# Altium schematic sheet Zotino.schdoc
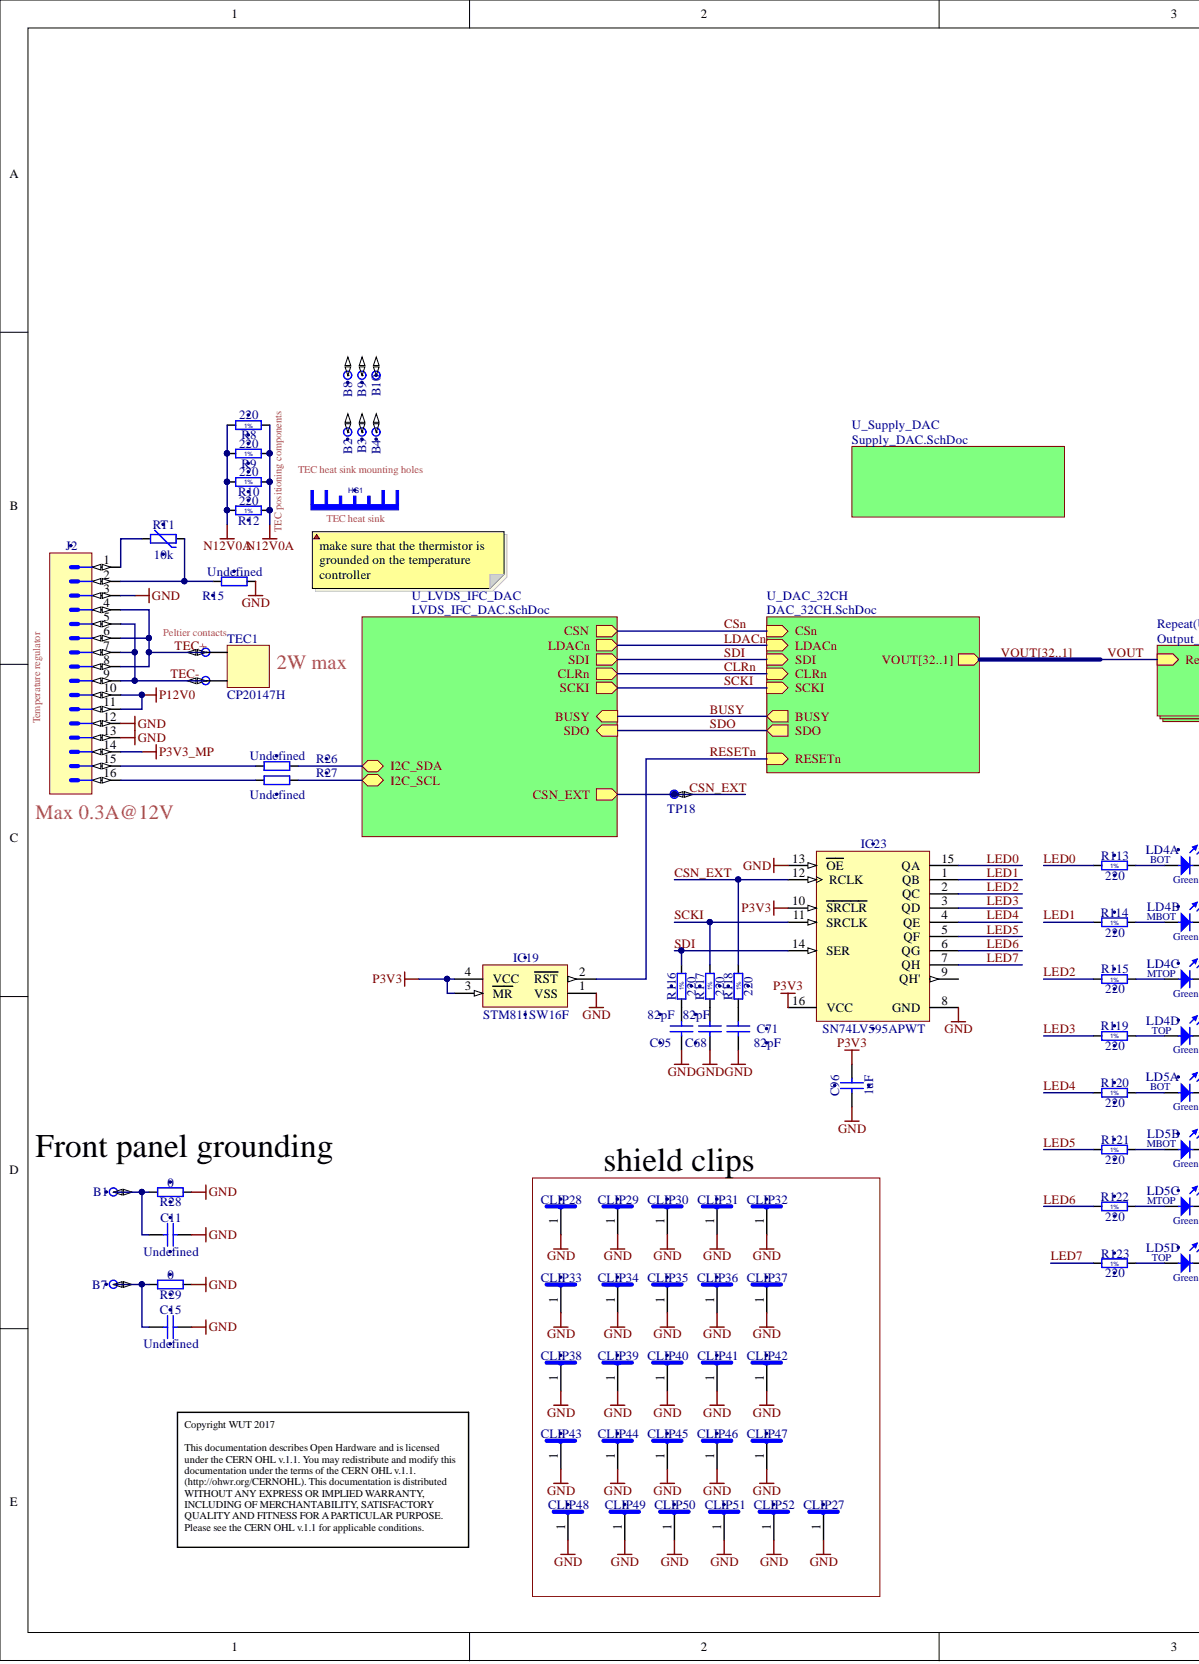
[diagram p0: Zotino.schdoc, left]
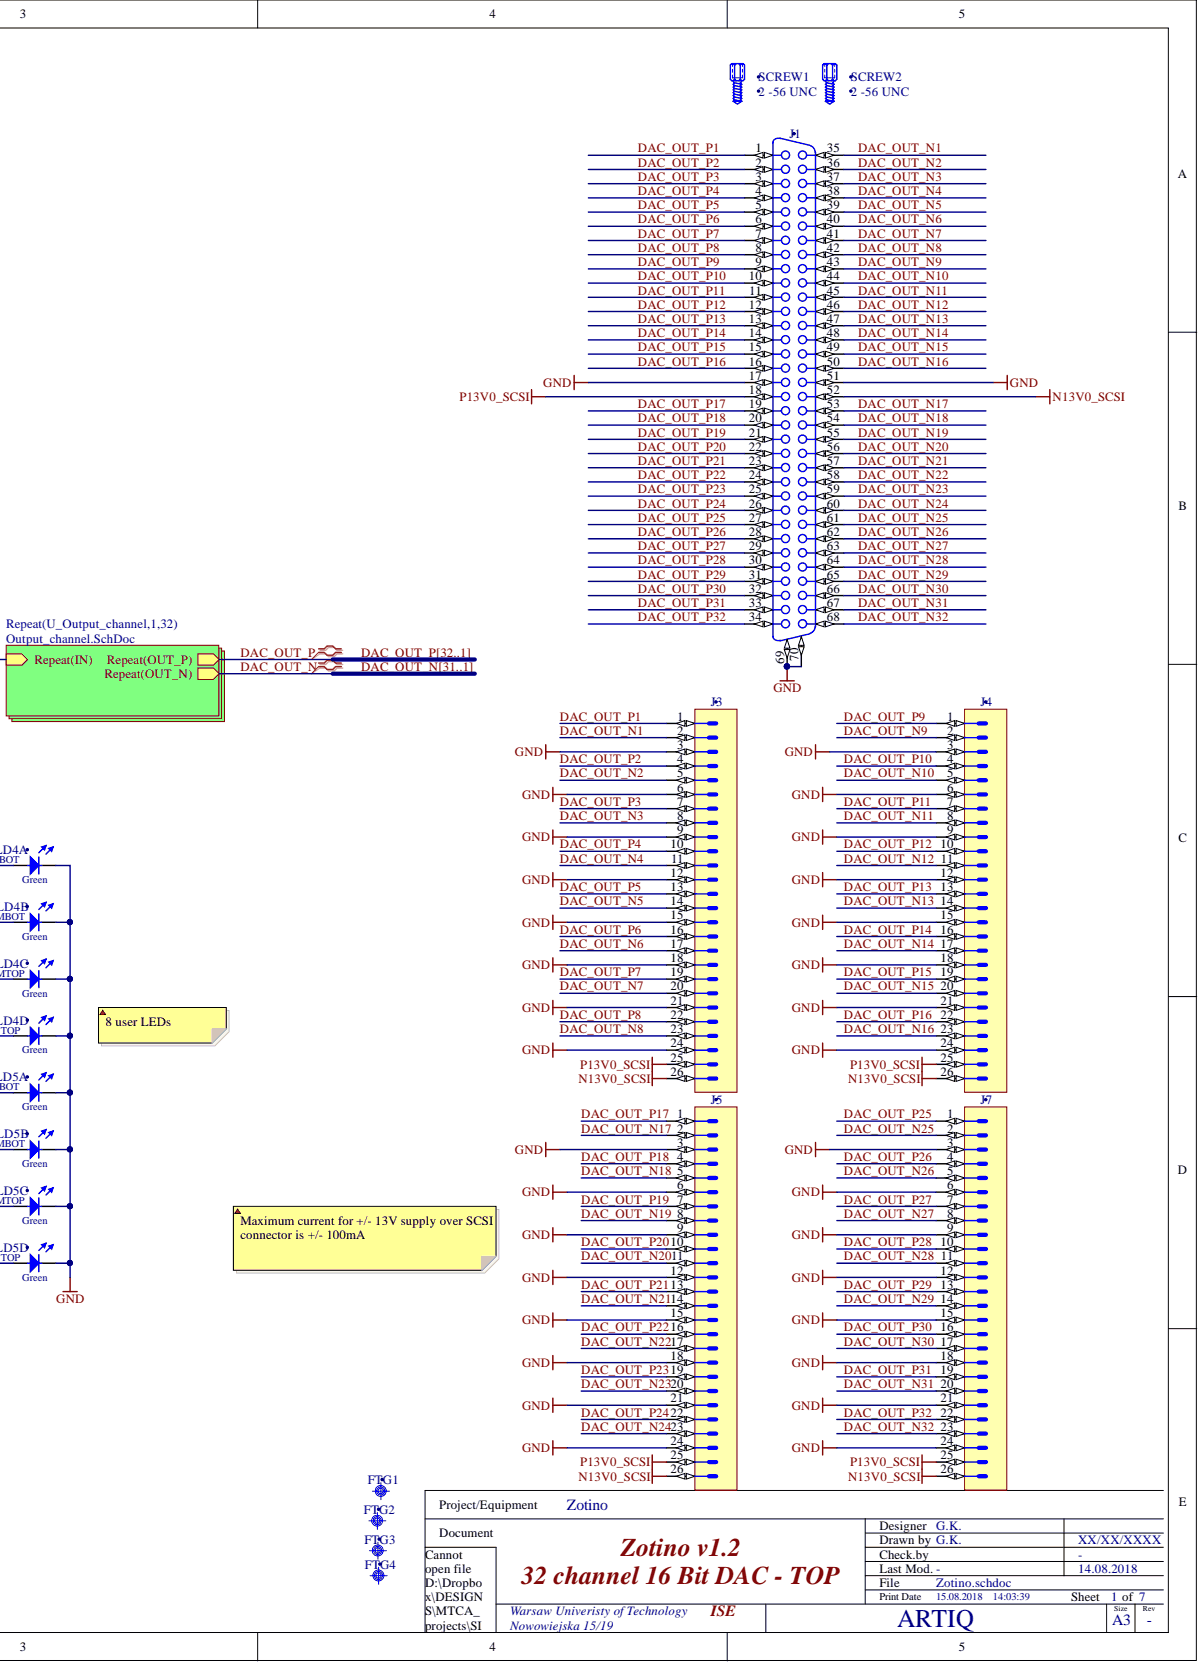
[diagram p1: Zotino.schdoc, right]

## Parts
B1  Plated Hole  [MTG270_500]  (value not drawn)  (no BOM)
B2  Plated Hole  [c130h80]  (value not drawn)  (no BOM)
B3  Plated Hole  [c130h80]  (value not drawn)  (no BOM)
B4  Plated Hole  [c130h80]  (value not drawn)  (no BOM)
B5  Plated Hole  [c160h110]  (value not drawn)  (no BOM)
B6  Plated Hole  [c160h110]  (value not drawn)  (no BOM)
B7  Plated Hole  [MTG270_500]  (value not drawn)  (no BOM)
B8  Plated Hole  [c130h80]  (value not drawn)  (no BOM)
B9  Plated Hole  [c130h80]  (value not drawn)  (no BOM)
B10  Plated Hole  [c130h80]  (value not drawn)  (no BOM)
C11  Undefined  [CAPC2012X135N]
C15  Undefined  [CAPC2012X135N]
C68  82pF  [CAPC1608X87N]
C71  82pF  [CAPC1608X87N]
C95  82pF  [CAPC1608X87N]
C96  1uF  [CAPC1608X87N]
CLIP27  S1411-46R  [CLIP_HOLLAND-SHIELDING_LC]  (value not drawn)
CLIP28  S1411-46R  [CLIP_HOLLAND-SHIELDING_LC]  (value not drawn)
CLIP29  S1411-46R  [CLIP_HOLLAND-SHIELDING_LC]  (value not drawn)
CLIP30  S1411-46R  [CLIP_HOLLAND-SHIELDING_LC]  (value not drawn)
CLIP31  S1411-46R  [CLIP_HOLLAND-SHIELDING_LC]  (value not drawn)
CLIP32  S1411-46R  [CLIP_HOLLAND-SHIELDING_LC]  (value not drawn)
CLIP33  S1411-46R  [CLIP_HOLLAND-SHIELDING_LC]  (value not drawn)
CLIP34  S1411-46R  [CLIP_HOLLAND-SHIELDING_LC]  (value not drawn)
CLIP35  S1411-46R  [CLIP_HOLLAND-SHIELDING_LC]  (value not drawn)
CLIP36  S1411-46R  [CLIP_HOLLAND-SHIELDING_LC]  (value not drawn)
CLIP37  S1411-46R  [CLIP_HOLLAND-SHIELDING_LC]  (value not drawn)
CLIP38  S1411-46R  [CLIP_HOLLAND-SHIELDING_LC]  (value not drawn)
CLIP39  S1411-46R  [CLIP_HOLLAND-SHIELDING_LC]  (value not drawn)
CLIP40  S1411-46R  [CLIP_HOLLAND-SHIELDING_LC]  (value not drawn)
CLIP41  S1411-46R  [CLIP_HOLLAND-SHIELDING_LC]  (value not drawn)
CLIP42  S1411-46R  [CLIP_HOLLAND-SHIELDING_LC]  (value not drawn)
CLIP43  S1411-46R  [CLIP_HOLLAND-SHIELDING_LC]  (value not drawn)
CLIP44  S1411-46R  [CLIP_HOLLAND-SHIELDING_LC]  (value not drawn)
CLIP45  S1411-46R  [CLIP_HOLLAND-SHIELDING_LC]  (value not drawn)
CLIP46  S1411-46R  [CLIP_HOLLAND-SHIELDING_LC]  (value not drawn)
CLIP47  S1411-46R  [CLIP_HOLLAND-SHIELDING_LC]  (value not drawn)
CLIP48  S1411-46R  [CLIP_HOLLAND-SHIELDING_LC]  (value not drawn)
CLIP49  S1411-46R  [CLIP_HOLLAND-SHIELDING_LC]  (value not drawn)
CLIP50  S1411-46R  [CLIP_HOLLAND-SHIELDING_LC]  (value not drawn)
CLIP51  S1411-46R  [CLIP_HOLLAND-SHIELDING_LC]  (value not drawn)
CLIP52  S1411-46R  [CLIP_HOLLAND-SHIELDING_LC]  (value not drawn)
FTG1  Use only in PCB  [FIDUCIAL_TOP_C100-200]  (value not drawn)  (no BOM)
FTG2  Use only in PCB  [FIDUCIAL_TOP_S100-200]  (value not drawn)  (no BOM)
FTG3  Use only in PCB  [FIDUCIAL_TOP_S200-400]  (value not drawn)  (no BOM)
FTG4  Use only in PCB  [FIDUCIAL_TOP_S100-200]  (value not drawn)  (no BOM)
HS1  Heat Sink No Pad  [HSINK_ATS_ATS-56001-C3-R0]  (value not drawn)
IC19  STM811SW16F  [SOT143N]
IC23  SN74LV595APWT  [SOP65P640X120-16N]
J1  D-SUB  [TYCO_5787082-7]  (value not drawn)
J2  Header 16  [3M_N2516-6002RB]  (value not drawn)
J3  Header 26  [3M_N2526-6002RB]  (value not drawn)
J4  Header 26  [3M_N2526-6002RB]  (value not drawn)
J5  Header 26  [3M_N2526-6002RB]  (value not drawn)
J7  Header 26  [3M_N2526-6002RB]  (value not drawn)
LD4  Green  [LED_BIVAR_H485CGDL]  (parts A,B,C,D)
LD5  Green  [LED_BIVAR_H485CGDL]  (parts A,B,C,D)
R8  220  [RESC1608X55N]
R9  220  [RESC1608X55N]
R10  220  [RESC1608X55N]
R12  220  [RESC1608X55N]
R15  Undefined  [RESC1608X55N]
R26  Undefined  [RESC1608X55N]
R27  Undefined  [RESC1608X55N]
R28  0  [RESC1608X55N]
R29  0  [RESC1608X55N]
R113  220  [RESC1608X55N]
R114  220  [RESC1608X55N]
R115  220  [RESC1608X55N]
R116  220  [RESC1608X55N]
R117  220  [RESC1608X55N]
R118  220  [RESC1608X55N]
R119  220  [RESC1608X55N]
R120  220  [RESC1608X55N]
R121  220  [RESC1608X55N]
R122  220  [RESC1608X55N]
R123  220  [RESC1608X55N]
RT1  10k  [THERMC1608X90N]
SCREW1  2 -56 UNC  [TYCO_786585-2]
SCREW2  2 -56 UNC  [TYCO_786585-2]
TEC1  CP20147H  [TEC_CP20147H]
TP18  Test Point  [TPS127]  (value not drawn)  (no BOM)

## Nets
GND: C11.2 C15.2 C68.1 C71.1 C95.1 C96.1 CLIP27.1 CLIP28.1 CLIP29.1 CLIP30.1 CLIP31.1 CLIP32.1 CLIP33.1 CLIP34.1 CLIP35.1 CLIP36.1 CLIP37.1 CLIP38.1 CLIP39.1 CLIP40.1 CLIP41.1 CLIP42.1 CLIP43.1 CLIP44.1 CLIP45.1 CLIP46.1 CLIP47.1 CLIP48.1 CLIP49.1 CLIP50.1 CLIP51.1 CLIP52.1 IC19.1(VSS) IC23.8(GND) IC23.13(OE) J1.17 J1.51 J1.69 J1.70 J2.3 J2.12 J2.13 J3.3 J3.6 J3.9 J3.12 J3.15 J3.18 J3.21 J3.24 J4.3 J4.6 J4.9 J4.12 J4.15 J4.18 J4.21 J4.24 J5.3 J5.6 J5.9 J5.12 J5.15 J5.18 J5.21 J5.24 J7.3 J7.6 J7.9 J7.12 J7.15 J7.18 J7.21 J7.24 LD4.1 LD4.3 LD4.5 LD4.7 LD5.1 LD5.3 LD5.5 LD5.7 R15.1 R28.1 R29.1
N12V0A: R8.1 R8.2 R9.1 R9.2 R10.1 R10.2 R12.1 R12.2
N13V0_SCSI: J1.52 J3.26 J4.26 J5.26 J7.26
P3V3: C96.2 IC19.3(MR) IC19.4(VCC) IC23.10(SRCLR) IC23.16(VCC)
P3V3_MP: J2.14
P12V0: J2.10 J2.11
P13V0_SCSI: J1.18 J3.25 J4.25 J5.25 J7.25
CSN_EXT: IC23.12(RCLK) R118.2 TP18.1
DAC_OUT_N1: J1.35 J3.2  [also labelled DAC_OUT_N]
DAC_OUT_N2: J1.36 J3.5  [also labelled DAC_OUT_N]
DAC_OUT_N3: J1.37 J3.8  [also labelled DAC_OUT_N]
DAC_OUT_N4: J1.38 J3.11  [also labelled DAC_OUT_N]
DAC_OUT_N5: J1.39 J3.14  [also labelled DAC_OUT_N]
DAC_OUT_N6: J1.40 J3.17  [also labelled DAC_OUT_N]
DAC_OUT_N7: J1.41 J3.20  [also labelled DAC_OUT_N]
DAC_OUT_N8: J1.42 J3.23  [also labelled DAC_OUT_N]
DAC_OUT_N9: J1.43 J4.2  [also labelled DAC_OUT_N]
DAC_OUT_N10: J1.44 J4.5  [also labelled DAC_OUT_N]
DAC_OUT_N11: J1.45 J4.8  [also labelled DAC_OUT_N]
DAC_OUT_N12: J1.46 J4.11  [also labelled DAC_OUT_N]
DAC_OUT_N13: J1.47 J4.14  [also labelled DAC_OUT_N]
DAC_OUT_N14: J1.48 J4.17  [also labelled DAC_OUT_N]
DAC_OUT_N15: J1.49 J4.20  [also labelled DAC_OUT_N]
DAC_OUT_N16: J1.50 J4.23  [also labelled DAC_OUT_N]
DAC_OUT_N17: J1.53 J5.2  [also labelled DAC_OUT_N]
DAC_OUT_N18: J1.54 J5.5  [also labelled DAC_OUT_N]
DAC_OUT_N19: J1.55 J5.8  [also labelled DAC_OUT_N]
DAC_OUT_N20: J1.56 J5.11  [also labelled DAC_OUT_N]
DAC_OUT_N21: J1.57 J5.14  [also labelled DAC_OUT_N]
DAC_OUT_N22: J1.58 J5.17  [also labelled DAC_OUT_N]
DAC_OUT_N23: J1.59 J5.20  [also labelled DAC_OUT_N]
DAC_OUT_N24: J1.60 J5.23  [also labelled DAC_OUT_N]
DAC_OUT_N25: J1.61 J7.2  [also labelled DAC_OUT_N]
DAC_OUT_N26: J1.62 J7.5  [also labelled DAC_OUT_N]
DAC_OUT_N27: J1.63 J7.8  [also labelled DAC_OUT_N]
DAC_OUT_N28: J1.64 J7.11  [also labelled DAC_OUT_N]
DAC_OUT_N29: J1.65 J7.14  [also labelled DAC_OUT_N]
DAC_OUT_N30: J1.66 J7.17  [also labelled DAC_OUT_N]
DAC_OUT_N31: J1.67 J7.20  [also labelled DAC_OUT_N]
DAC_OUT_N32: J1.68 J7.23  [also labelled DAC_OUT_N]
DAC_OUT_P1: J1.1 J3.1  [also labelled DAC_OUT_P]
DAC_OUT_P2: J1.2 J3.4  [also labelled DAC_OUT_P]
DAC_OUT_P3: J1.3 J3.7  [also labelled DAC_OUT_P]
DAC_OUT_P4: J1.4 J3.10  [also labelled DAC_OUT_P]
DAC_OUT_P5: J1.5 J3.13  [also labelled DAC_OUT_P]
DAC_OUT_P6: J1.6 J3.16  [also labelled DAC_OUT_P]
DAC_OUT_P7: J1.7 J3.19  [also labelled DAC_OUT_P]
DAC_OUT_P8: J1.8 J3.22  [also labelled DAC_OUT_P]
DAC_OUT_P9: J1.9 J4.1  [also labelled DAC_OUT_P]
DAC_OUT_P10: J1.10 J4.4  [also labelled DAC_OUT_P]
DAC_OUT_P11: J1.11 J4.7  [also labelled DAC_OUT_P]
DAC_OUT_P12: J1.12 J4.10  [also labelled DAC_OUT_P]
DAC_OUT_P13: J1.13 J4.13  [also labelled DAC_OUT_P]
DAC_OUT_P14: J1.14 J4.16  [also labelled DAC_OUT_P]
DAC_OUT_P15: J1.15 J4.19  [also labelled DAC_OUT_P]
DAC_OUT_P16: J1.16 J4.22  [also labelled DAC_OUT_P]
DAC_OUT_P17: J1.19 J5.1  [also labelled DAC_OUT_P]
DAC_OUT_P18: J1.20 J5.4  [also labelled DAC_OUT_P]
DAC_OUT_P19: J1.21 J5.7  [also labelled DAC_OUT_P]
DAC_OUT_P20: J1.22 J5.10  [also labelled DAC_OUT_P]
DAC_OUT_P21: J1.23 J5.13  [also labelled DAC_OUT_P]
DAC_OUT_P22: J1.24 J5.16  [also labelled DAC_OUT_P]
DAC_OUT_P23: J1.25 J5.19  [also labelled DAC_OUT_P]
DAC_OUT_P24: J1.26 J5.22  [also labelled DAC_OUT_P]
DAC_OUT_P25: J1.27 J7.1  [also labelled DAC_OUT_P]
DAC_OUT_P26: J1.28 J7.4  [also labelled DAC_OUT_P]
DAC_OUT_P27: J1.29 J7.7  [also labelled DAC_OUT_P]
DAC_OUT_P28: J1.30 J7.10  [also labelled DAC_OUT_P]
DAC_OUT_P29: J1.31 J7.13  [also labelled DAC_OUT_P]
DAC_OUT_P30: J1.32 J7.16  [also labelled DAC_OUT_P]
DAC_OUT_P31: J1.33 J7.19  [also labelled DAC_OUT_P]
DAC_OUT_P32: J1.34 J7.22  [also labelled DAC_OUT_P]
LED0: IC23.15(QA) R113.1
LED1: IC23.1(QB) R114.1
LED2: IC23.2(QC) R115.1
LED3: IC23.3(QD) R119.1
LED4: IC23.4(QE) R120.1
LED5: IC23.5(QF) R121.1
LED6: IC23.6(QG) R122.1
LED7: IC23.7(QH) R123.1
RESETn: IC19.2(RST)
SCKI: IC23.11(SRCLK) R117.2
SDI: IC23.14(SER) R116.2
TEC+: B5.1 J2.4 J2.6 J2.8
TEC-: B6.1 J2.5 J2.7 J2.9
NetB1_1: B1.1 C11.1 R28.2
NetB2_1: B2.1
NetB3_1: B3.1
NetB4_1: B4.1
NetB7_1: B7.1 C15.1 R29.2
NetB8_1: B8.1
NetB9_1: B9.1
NetB10_1: B10.1
NetC68_2: C68.2 R117.1
NetC71_2: C71.2 R118.1
NetC95_2: C95.2 R116.1
NetIC23_9: IC23.9(QH')
NetJ2_1: J2.1 RT1.1
NetJ2_2: J2.2 R15.2 RT1.2
NetJ2_15: J2.15 R26.2
NetJ2_16: J2.16 R27.2
NetLD4_2: LD4.2 R113.2
NetLD4_4: LD4.4 R114.2
NetLD4_6: LD4.6 R115.2
NetLD4_8: LD4.8 R119.2
NetLD5_2: LD5.2 R120.2
NetLD5_4: LD5.4 R121.2
NetLD5_6: LD5.6 R122.2
NetLD5_8: LD5.8 R123.2
NetR26_1: R26.1
NetR27_1: R27.1
BUSY:
CLRn:
CSn:
LDACn:
SDO:
VOUT1:  [also labelled VOUT]
VOUT2:  [also labelled VOUT]
VOUT3:  [also labelled VOUT]
VOUT4:  [also labelled VOUT]
VOUT5:  [also labelled VOUT]
VOUT6:  [also labelled VOUT]
VOUT7:  [also labelled VOUT]
VOUT8:  [also labelled VOUT]
VOUT9:  [also labelled VOUT]
VOUT10:  [also labelled VOUT]
VOUT11:  [also labelled VOUT]
VOUT12:  [also labelled VOUT]
VOUT13:  [also labelled VOUT]
VOUT14:  [also labelled VOUT]
VOUT15:  [also labelled VOUT]
VOUT16:  [also labelled VOUT]
VOUT17:  [also labelled VOUT]
VOUT18:  [also labelled VOUT]
VOUT19:  [also labelled VOUT]
VOUT20:  [also labelled VOUT]
VOUT21:  [also labelled VOUT]
VOUT22:  [also labelled VOUT]
VOUT23:  [also labelled VOUT]
VOUT24:  [also labelled VOUT]
VOUT25:  [also labelled VOUT]
VOUT26:  [also labelled VOUT]
VOUT27:  [also labelled VOUT]
VOUT28:  [also labelled VOUT]
VOUT29:  [also labelled VOUT]
VOUT30:  [also labelled VOUT]
VOUT31:  [also labelled VOUT]
VOUT32:  [also labelled VOUT]

## Library data of the parts
B1 B7 = PLATED_HOLE2.7_PAD5.0: Single Terminal Socket; Part Description: Plated Through Hole: Hole Dia.=2.7mm Pad Dia.=5.0mm; Database Table Name: Pads; Mounted: No; ComponentHeight: 0mm; Component Type: Standard (No BOM); CreateDate: 27.09.2011; Family: Plated Hole; HelpURL: Undefined; LatestRevisionDate: 27.09.2011; Library Name: Eletro-mechanical.DbLib; PackageDescription: Plated Through Hole: Hole Dia.=2.7mm Pad Dia.=5.0mm; Part Number: PLATED_HOLE2.7_PAD5.0; Pin Count: 1; Sense: No; SMD: No; Socket: No; Status: None; Author: CERN DEM JMW
B2-B4 B8-B10 = PLATED_HOLE0.8_PAD1.3: Single Terminal Socket; Part Description: Plated Through Hole: Hole Dia.=0.8mm Pad Dia.=1.3mm, IPC-7251 Level C; Database Table Name: Pads; Mounted: No; ComponentHeight: 0mm; Component Type: Standard (No BOM); CreateDate: 30.10.2008; Family: Plated Hole; HelpURL: Undefined; LatestRevisionDate: 30.10.2008; Library Name: Eletro-mechanical.DbLib; PackageDescription: Plated Through Hole: Hole Dia.=0.8mm Pad Dia.=1.3mm, IPC-7251 Level C; Part Number: PLATED_HOLE0.8_PAD1.3; Pin Count: 1; Sense: No; SMD: No; Socket: No; Status: None; Author: CERN DEM JLC
B5 B6 = PLATED_HOLE1.1_PAD1.6: Single Terminal Socket; Part Description: Plated Through Hole: Hole Dia.=1.1mm Pad Dia.=1.6mm, IPC-7251 Level C; Database Table Name: Pads; Mounted: No; ComponentHeight: 0mm; Component Type: Standard (No BOM); CreateDate: 30.10.2008; Family: Plated Hole; HelpURL: Undefined; LatestRevisionDate: 30.10.2008; Library Name: Eletro-mechanical.DbLib; PackageDescription: Plated Through Hole: Hole Dia.=1.1mm Pad Dia.=1.6mm, IPC-7251 Level C; Part Number: PLATED_HOLE1.1_PAD1.6; Pin Count: 1; Sense: No; SMD: No; Socket: No; Status: None; Author: CERN DEM JLC
C11 C15 = CC0805_NO-VALUE: Non-polarised capacitor; Part Description: Reserved Footprint For 0805(2012) Chip Ceramic Capacitor; Database Table Name: Capacitors SMD; Mounted: No; Case: 0805; Component Kind: Standard; Component Type: Standard; CreateDate: 10.12.2008; HelpURL: Undefined; LatestRevisionDate: 11.09.2013; Library Name: Capacitors.DbLib; Manufacturer: Undefined; Manufacturer1 ComponentHeight: 1.35mm; Manufacturer Part Number: CC0805_NO-VALUE; Part Number: CC0805_NO-VALUE; Pin Count: 2; Sense: No; SMD: Yes; Socket: No; Status: None; Value: Undefined; Author: CERN DEM JLC
C68 C71 C95 = CC0603_82PF_50V_5%_NP0: Non-polarised capacitor; Manufacturer: GENERIC; Manufacturer1 Part Number: 223886715829; Part Description: SMD Multilayer Chip Ceramic Capacitor; Database Table Name: Capacitors SMD; TC: C0G/NP0; Tolerance: ±5%; Voltage: 50V; Case: 0603; Component Kind: Standard; Component Type: Standard; CreateDate: 03.12.2007; HelpURL: \\cern.ch\dfs\Applications\Altium\Datasheets\CC0603_NP0_PHYCOMP.pdf; LatestRevisionDate: 03.12.2007; Library Name: Capacitors.DbLib; Manufacturer1 ComponentHeight: 0.87mm; Manufacturer1 Example: PHYCOMP; Manufacturer Part Number: CC0603_82PF_50V_5%_NP0; Mounted: Yes; Part Number: CC0603_82PF_50V_5%_NP0; Pin Count: 2; Sense: No; SMD: Yes; Socket: No; Status: Preferred; Value: 82pF; Author: CERN DEM JLC
C96 = CC0603_1UF_25V_10%_X7R: Non-polarised capacitor; Manufacturer: GENERIC; Manufacturer1 Part Number: GRM188R71E105KA12D; Part Description: SMD Multilayer Chip Ceramic Capacitor; Database Table Name: Capacitors SMD; TC: X7R; Tolerance: ±10%; Voltage: 25V; Case: 0603; Component Kind: Standard; Component Type: Standard; CreateDate: 18.01.2011; HelpURL: \\cern.ch\dfs\Applications\Altium\Datasheets\CC0603_X7R_MURATA.pdf; LatestRevisionDate: 18.01.2011; Library Name: Capacitors.DbLib; Manufacturer1 ComponentHeight: 0.9mm; Manufacturer1 Example: MURATA; Manufacturer Part Number: CC0603_1UF_25V_10%_X7R; Mounted: Yes; Part Number: CC0603_1UF_25V_10%_X7R; Pin Count: 2; Sense: No; SMD: Yes; Socket: No; Status: None; Value: 1uF; Author: CERN DEM JLC
CLIP27-CLIP52 = (no symbol name): Surface Mount Clip for PCB Screening Covers; Manufacturer: HARWIN; Part Description: Surface Mount Clip for PCB Screening Covers, 1500 Serie; PressFit: No; Table: Metal Screening Box; ComponentHeight: 3.5mm; Component Kind: Standard; Component Type: Standard; CreateDate: 2013-06-25; HelpURL: \\cern.ch\dfs\Applications\Altium\Datasheets\CLIP_HOLLAND-SHIELDING_LC.pdf; LatestRevisionDate: 2013-06-25; Library Name: LIBRARY_SCH.SCHLIB; Manufacturer Part Number: S1411-46R; Mounted: Yes; PackageDescription: Surface Mount Clip for PCB Screening Covers, 1500 Serie; Part Number: S1411-46R; Pin Count: 1; SMD: Yes; Socket: No; Status: None; Author: CERN DEM JLC
FTG1 = FIDUCIAL_TARGET_C100-200: Fiducial Target; Part Description: Round Fiducial Target, Top Layer, 1.0mm, Top Solder 2.0mm, Keepout =2.0mm; Database Table Name: Pads; Mounted: No; ComponentHeight: 0mm; Component Type: Standard (No BOM); CreateDate: 09.02.2010; Family: Fiducial; HelpURL: Undefined; LatestRevisionDate: 04.04.2012; Library Name: Eletro-mechanical.DbLib; PackageDescription: Round Fiducial Target, Top Layer, 1.0mm, Top Solder 2.0mm, Keepout =2.0mm; Part Number: FIDUCIAL_TARGET_C100-200; Pin Count: 1; Sense: No; SMD: Yes; Socket: No; Status: None; Author: CERN DEM JLC
FTG2 FTG4 = FIDUCIAL_TARGET_S100-200: Fiducial Target; Part Description: Square Fiducial Target, Top Layer, 1.0mm, Top Solder 2.0mm, Keepout =2.0mm; Database Table Name: Pads; Mounted: No; ComponentHeight: 0mm; Component Type: Standard (No BOM); CreateDate: 09.02.2010; Family: Fiducial; HelpURL: Undefined; LatestRevisionDate: 04.04.2012; Library Name: Eletro-mechanical.DbLib; PackageDescription: Square Fiducial Target, Top Layer, 1.0mm, Top Solder 2.0mm, Keepout =2.0mm; Part Number: FIDUCIAL_TARGET_S100-200; Pin Count: 1; Sense: No; SMD: Yes; Socket: No; Status: None; Author: CERN DEM JLC
FTG3 = FIDUCIAL_TARGET_S200-400: Fiducial Target; Part Description: Square Fiducial Target, Top Layer, 2.0mm, Top Solder 4.0mm, Keepout =4.0mm; Database Table Name: Pads; Mounted: No; ComponentHeight: 0mm; Component Type: Standard (No BOM); CreateDate: 02.06.2008; Family: Fiducial; HelpURL: Undefined; LatestRevisionDate: 04.04.2012; Library Name: Eletro-mechanical.DbLib; PackageDescription: Square Fiducial Target, Top Layer, 2.0mm, Top Solder 4.0mm, Keepout =4.0mm; Part Number: FIDUCIAL_TARGET_S200-400; Pin Count: 1; Sense: No; SMD: Yes; Socket: No; Status: None; Author: CERN DEM JLC
HS1 = (no symbol name): Heat Sink Without Pad; Manufacturer: ADVENCED THERMAL SOLUTION (ATS); Manufacturer Part Number: ATS-56001-C3-R0; Part Description: 19 x 19 x 9 mm BGA Heat Sink - High Performance  maxiFLOW/maxiGRIP-STD; PressFit: No; ComponentHeight: 9mm; Component Kind: Standard; Component Type: Standard; CreateDate: 30.11.2016; HelpURL: \\cern.ch\dfs\Applications\Altium\Datasheets\HSINK_ATS_ATS-50350B-C1-R0.pdf; LatestRevisionDate: 30.11.2016; Library Name: LIBRARY_SCH.SCHLIB; Mounted: Yes; PackageDescription: 19 x 19 x 12.5 mm BGA Heat Sink, Body 19mm x 19mm  Height 9mm; Part Number: HSINK_ATS_ATS-56001-C3-R0; Pin Count: 0; Sense: No; SMD: No; Socket: No; Status: None; Author: GK
IC19 = STM811SW16F: Microprocessor Reset Circuit; Manufacturer: ST MICROELECTRONICS; Part Description: 2.93V Reset Threshold Voltage Microprocessor Reset Circuit; Bonding: No; Database Table Name: Analog & Interface; PressFit: No; Case: SOT143; ComponentHeight: 1.12mm; Component Kind: Standard; Component Type: Standard; CreateDate: 17.11.2016; Device: STM811SW16F; HelpURL: \\cern.ch\dfs\Applications\Altium\Datasheets\STM811SW16F.pdf; LatestRevisionDate: 17.11.2016; Library Name: ICs And Semiconductors.DbLib; Manufacturer Part Number: STM811SW16F; Mounted: Yes; PackageDescription: SOT143, 4-Leads, Body 3.0x2.6mm (max), Pitch 1.92mm, IPC Medium Density; Part Number: STM811SW16F; Pin Count: 4; Sense: No; SMD: Yes; Socket: No; Author: CERN DEM JLC
IC23 = SN74LV595APWT: 8-Bit Shift Register With 3-State Output Register; Manufacturer: TEXAS INSTRUMENTS; Bonding: No; Database Table Name: Standard Logic; PressFit: No; Case: TSSOP16; ComponentHeight: 1.2mm; Component Kind: Standard; Component Type: Standard; CreateDate: 10.05.2012; Device: SN74LV595APWT; HelpURL: \\cern.ch\dfs\Applications\Altium\Datasheets\SN74LV595APWT.pdf; LatestRevisionDate: 10.05.2012; Library Name: ICs And Semiconductors.DbLib; Manufacturer Part Number: SN74LV595APWT; Mounted: Yes; PackageDescription: SOP, 0.65mm pitch; 16 pin, 4.40mm W X 5.00mm L X 1.20mm H body IPC Medium Density; Part Description: 8-Bit Shift Register With 3-State Output Register; Part Number: SN74LV595APWT; Pin Count: 16; Sense: No; SMD: Yes; Socket: No; Author: CERN DEM JLC
J1 = TYCO_5787082-7: 68 contacts, AMPLIMITE .050", Right Angled Receptacle Series III; Manufacturer: TYCO ELECTRONICS; Database Table Name: TYCO; PressFit: No; ComponentHeight: 9.5mm; Component Kind: Standard; Component Type: Standard; CreateDate: 13.03.2017; Family: D-SUB; HelpURL: \\cern.ch\dfs\Applications\Altium\Datasheets\TYCO_5787082-7.pdf; LatestRevisionDate: 13.03.2017; Library Name: Connectors.DbLib; Manufacturer Part Number: 5787082-7; Mounted: Yes; Part Description: 68 contacts, AMPLIMITE .050", Right Angled Receptacle Series III; Part Number: TYCO_5787082-7; Pin Count: 70; Sense: No; SMD: No; Socket: No; Status: None; Author: CERN DEM JLC
J2 = 3M_N2516-6002RB: Connector 16 Male; Part Description: 16 Contacts - Straight .100'' x .100'' Low Profile Four-Wall Header, 2500 Series; Database Table Name: 3M; PressFit: No; ComponentHeight: 10.03mm; Component Kind: Standard; Component Type: Standard; CreateDate: 11.02.2009; Family: Header 16; HelpURL: \\cern.ch\dfs\Applications\Altium\Datasheets\3M_N2516-6002RB.pdf; LatestRevisionDate: 11.08.2009; Library Name: Connectors.DbLib; Manufacturer: 3M; Manufacturer Part Number: N2516-6002RB; Mounted: Yes; Part Number: 3M_N2516-6002RB; Pin Count: 16; Sense: No; SMD: No; Socket: No; Status: None; Author: CERN DEM JLC
J3-J5 J7 = 3M_N2526-6002RB: Connector 26 Male; Part Description: 26 Contacts - Straight .100'' x .100'' Low Profile Four-Wall Header, 2500 Series; Database Table Name: 3M; PressFit: No; ComponentHeight: 10.03mm; Component Kind: Standard; Component Type: Standard; CreateDate: 11.02.2009; Family: Header 26; HelpURL: \\cern.ch\dfs\Applications\Altium\Datasheets\3M_N2526-6002RB.pdf; LatestRevisionDate: 11.08.2009; Library Name: Connectors.DbLib; Manufacturer: 3M; Manufacturer Part Number: N2526-6002RB; Mounted: Yes; Part Number: 3M_N2526-6002RB; Pin Count: 26; Sense: No; SMD: No; Socket: No; Status: None; Author: CERN DEM JLC
LD4 LD5 = LED_BIVAR_H485CGDL: LED x4 Green 1C2A-BOT_3C4A_5C6A_7C8A-TOP; Manufacturer: BIVAR; Part Description: Quad-Level 2mm Green LED, PCB Edge Mounting; Bonding: No; Database Table Name: LEDs & Displays; PressFit: No; Color: Green; ComponentHeight: 11.3mm; Component Kind: Standard; Component Type: Standard; CreateDate: 04.06.2012; HelpURL: \\cern.ch\dfs\Applications\Altium\Datasheets\LED_BIVAR_H485CGDL.pdf; LatestRevisionDate: 04.06.2012; Library Name: ICs And Semiconductors.DbLib; Manufacturer Part Number: H485CGDL; Mounted: Yes; PackageDescription: Quad-Level 2mm Green, PCB Edge Mounting  BIVAR H485CHDL; Part Number: LED_BIVAR_H485CGDL; Pin Count: 8; Sense: No; SMD: No; Socket: No; Author: CERN DEM JLC
R8-R10 R12 R113-R123 = R0603_220R_1%_0.1W_100PPM: Resistor - 1%; Manufacturer: GENERIC; Manufacturer1 Part Number: NRC06F2200TRF; Part Description: General Purpose Thick Film Chip Resistor; Database Table Name: Resistors SMD; Power: 0.1W; TC: ±100ppm/°C; Case: 0603; Component Kind: Standard; Component Type: Standard; CreateDate: 03.12.2007; HelpURL: \\cern.ch\dfs\Applications\Altium\Datasheets\R0603_NIC_NRC.pdf; LatestRevisionDate: 13.03.2008; Library Name: Resistors.DbLib; Manufacturer1 ComponentHeight: 0.55mm; Manufacturer1 Example: NIC COMPONENT; Manufacturer Part Number: R0603_220R_1%_0.1W_100PPM; Mounted: Yes; Part Number: R0603_220R_1%_0.1W_100PPM; Pin Count: 2; Sense: No; SMD: Yes; Socket: No; Status: Preferred; Tolerance: ±1%; Value: 220; Author: CERN DEM JLC
R15 R26 R27 = R0603_NO-VALUE: Resistor; Part Description: Reserved Footprint For a 0603 (1608) Chip Resistor; Database Table Name: Resistors SMD; Mounted: No; Case: 0603; Component Kind: Standard; Component Type: Standard; CreateDate: 03.12.2007; HelpURL: Undefined; LatestRevisionDate: 13.03.2008; Library Name: Resistors.DbLib; Manufacturer: Undefined; Manufacturer1 ComponentHeight: Undefined; Manufacturer1 Example: Undefined; Manufacturer1 Part Number: Undefined; Manufacturer Part Number: R0603_NO-VALUE; Part Number: R0603_NO-VALUE; Pin Count: 2; Sense: No; SMD: Yes; Socket: No; Status: None; Value: Undefined; Author: CERN DEM JLC
R28 R29 = R0603_0R_JUMPER: Resistor; Manufacturer: GENERIC; Manufacturer1 Part Number: NRC06Z0; Part Description: 1A (0.05R Max DC Resistance) Zero Ohm Jumper; Database Table Name: Resistors SMD; PressFit: No; Case: 0603; Component Kind: Standard; Component Type: Standard; CreateDate: 03.12.2007; HelpURL: \\cern.ch\dfs\Applications\Altium\Datasheets\R0603_NIC_NRC.pdf; LatestRevisionDate: 13.03.2008; Library Name: Resistors.DbLib; Manufacturer1 ComponentHeight: 0.55mm; Manufacturer1 Example: NIC COMPONENT; Manufacturer Part Number: R0603_0R_JUMPER; Mounted: Yes; Part Number: R0603_0R_JUMPER; Pin Count: 2; Sense: No; SMD: Yes; Socket: No; Status: Preferred; Value: 0; Author: CERN DEM JLC
RT1 = THERM_NTC_10K_EPCOS_B57330V2103J260: Thermistor NTC (Negative Temperature Coefficient); Manufacturer: EPCOS; Part Description: SMD NTC Thermistor for Temperature Measurement; Database Table Name: Thermistors And Varistors; Power: 180mW; Tolerance: ±5%; Case: 0603; ComponentHeight: 0.9mm; Component Kind: Standard; Component Type: Standard; CreateDate: 30.07.2015; HelpURL: \\cern.ch\dfs\Applications\Altium\Datasheets\THERM_EPCOS_B573xxV2.pdf; LatestRevisionDate: 30.07.2015; Library Name: Resistors.DbLib; Manufacturer Part Number: B57330V2103J260; Mounted: Yes; Part Number: THERM_NTC_10K_EPCOS_B57330V2103J260; Pin Count: 2; Sense: No; SMD: Yes; Socket: No; Status: None; Value: 10k; Author: CERN DEM BC
SCREW1 SCREW2 = (no symbol name): SCSI Screw Lock; Manufacturer: TYCO ELECTRONICS; Manufacturer Part Number: 786585-2; Part Description: 50SR SCREWLOCK KIT, FEMALE; PressFit: No; ComponentHeight: 9.02mm; Component Kind: Standard; Component Type: Mechanical; CreateDate: 10.09.2013; LatestRevisionDate: 10.09.2013; Library Name: LIBRARY_SCH.SCHLIB; Mounted: Yes; PackageDescription: 50SR SCREWLOCK KIT, FEMALE; Part Number: TYCO_786585-2; Pin Count: 0; Sense: No; SMD: No; Socket: No; Status: None; Value: 2 -56 UNC; Author: GK
TEC1 = TEC_CP20147H: Peltier Module 15x15 mm; Component Kind: Standard; Library Name: LIBRARY_SCH.SCHLIB
TP18 = SMD_PAD_1.27X1.27MM: Part Description: 1.27mm x 1.27mm PCB Copper Area (SMD Test Point); Database Table Name: Pads; Mounted: No; ComponentHeight: 0mm; Component Type: Standard (No BOM); CreateDate: 12.01.2008; Description: Test Point; Family: Test Point; HelpURL: Undefined; LatestRevisionDate: 12.01.2008; Library Name: Eletro-mechanical.DbLib; PackageDescription: 1.27mm x 1.27mm PCB Copper Area (SMD Test Point); Part Number: SMD_PAD_1.27X1.27MM; Pin Count: 1; Sense: No; SMD: Yes; Socket: No; Status: None; Author: CERN DEM JLC
